# Altium schematic sheet Input.SchDoc(Input)
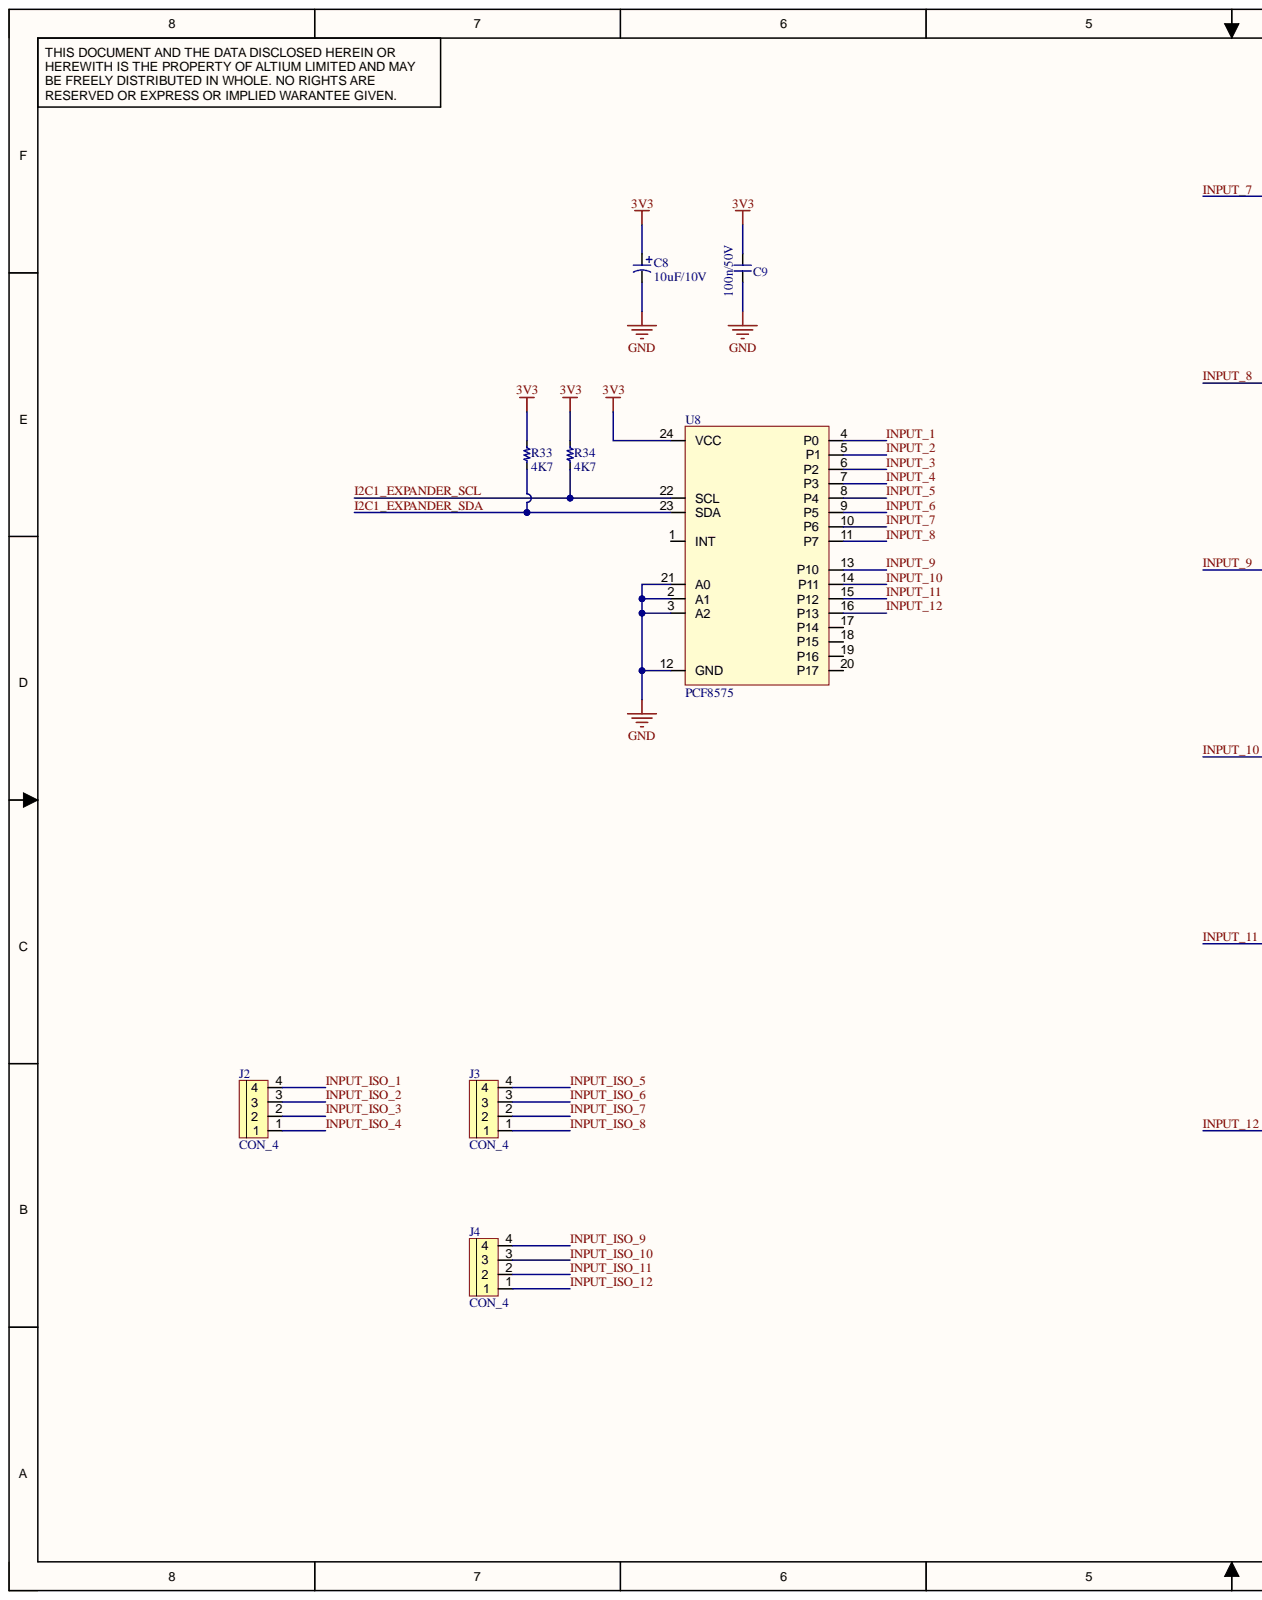
[diagram p0: Input.SchDoc, left]
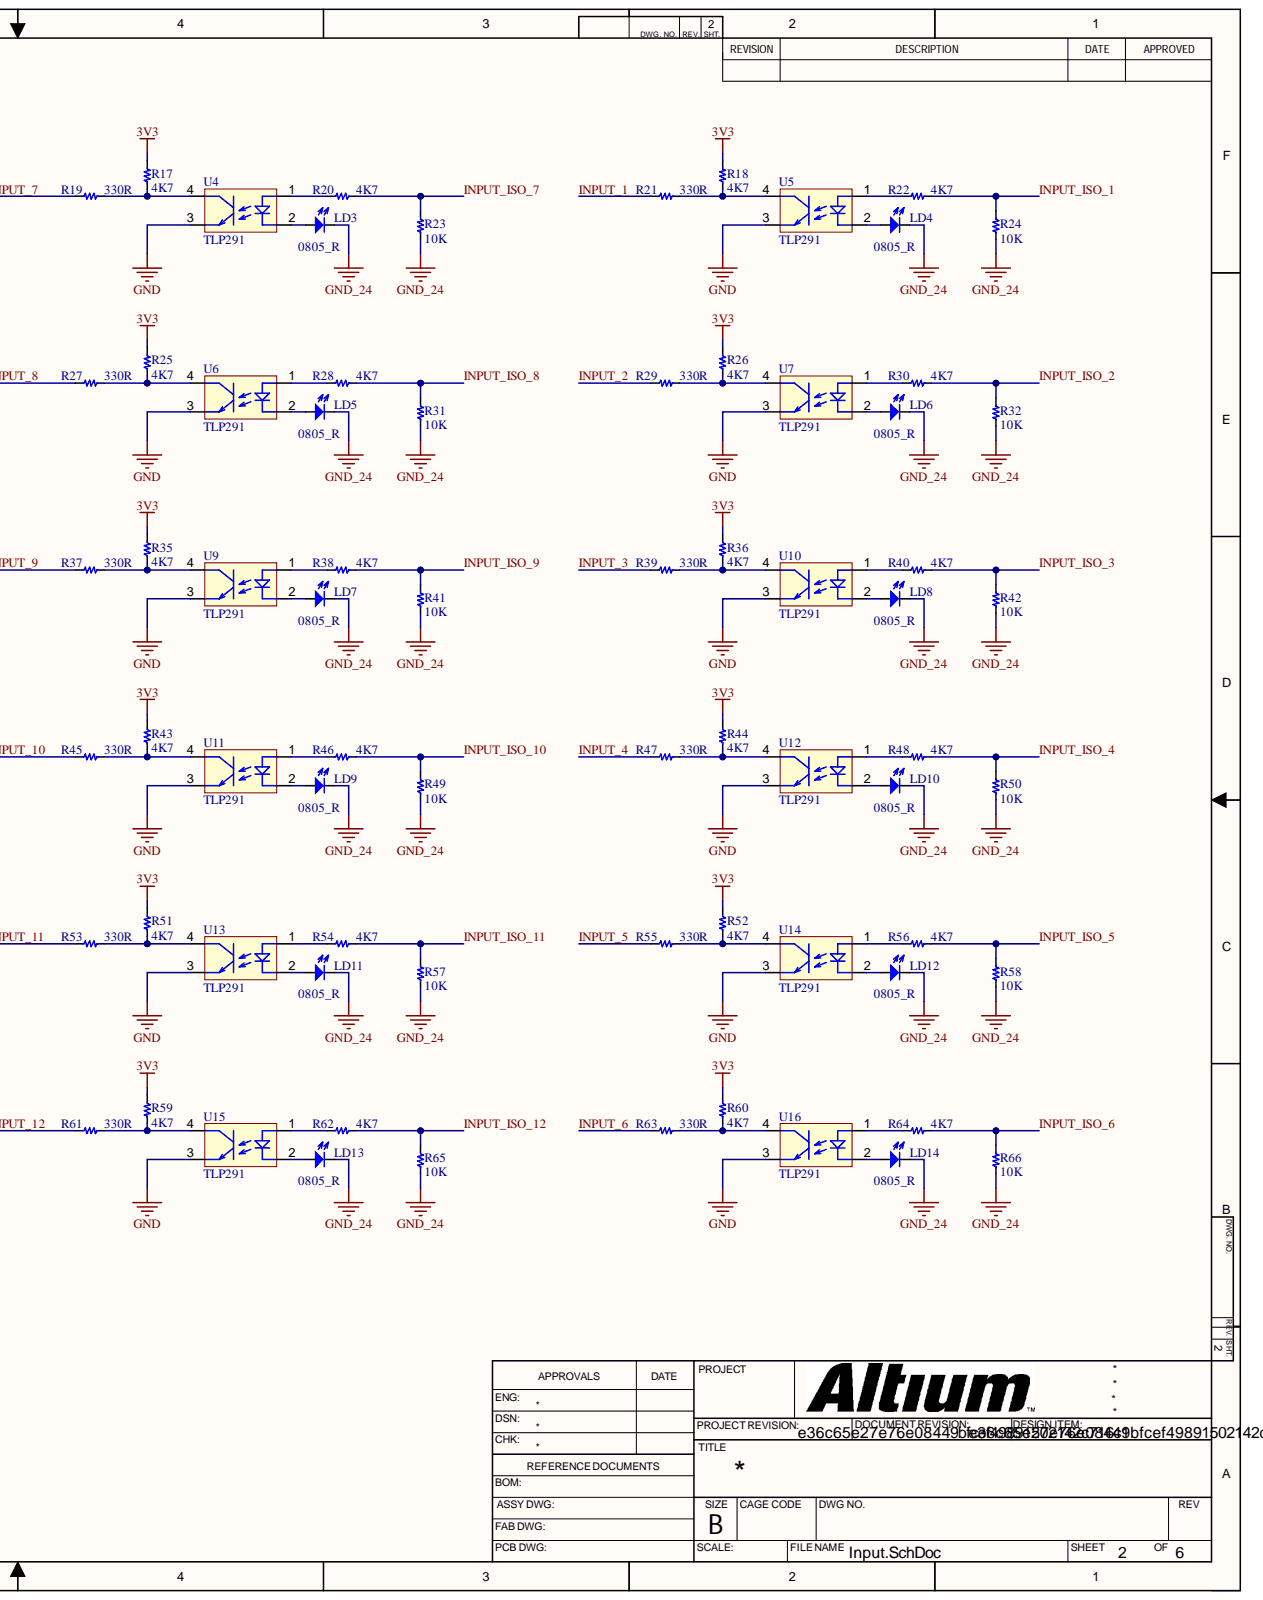
[diagram p1: Input.SchDoc, right]

## Parts
C8  10uF/10V  [CAP_TANTA_CASE_A]
C9  100n/50V  [C-0805]
J2  CON_4  [KF-5.0-4P]
J3  CON_4  [KF-5.0-4P]
J4  CON_4  [KF-5.0-4P]
LD3  0805_R  [LED_0805_R]
LD4  0805_R  [LED_0805_R]
LD5  0805_R  [LED_0805_R]
LD6  0805_R  [LED_0805_R]
LD7  0805_R  [LED_0805_R]
LD8  0805_R  [LED_0805_R]
LD9  0805_R  [LED_0805_R]
LD10  0805_R  [LED_0805_R]
LD11  0805_R  [LED_0805_R]
LD12  0805_R  [LED_0805_R]
LD13  0805_R  [LED_0805_R]
LD14  0805_R  [LED_0805_R]
R17  4K7  [R-0805]
R18  4K7  [R-0805]
R19  330R  [R-0805]
R20  4K7  [R-0805]
R21  330R  [R-0805]
R22  4K7  [R-0805]
R23  10K  [R-0805]
R24  10K  [R-0805]
R25  4K7  [R-0805]
R26  4K7  [R-0805]
R27  330R  [R-0805]
R28  4K7  [R-0805]
R29  330R  [R-0805]
R30  4K7  [R-0805]
R31  10K  [R-0805]
R32  10K  [R-0805]
R33  4K7  [R-0805]
R34  4K7  [R-0805]
R35  4K7  [R-0805]
R36  4K7  [R-0805]
R37  330R  [R-0805]
R38  4K7  [R-0805]
R39  330R  [R-0805]
R40  4K7  [R-0805]
R41  10K  [R-0805]
R42  10K  [R-0805]
R43  4K7  [R-0805]
R44  4K7  [R-0805]
R45  330R  [R-0805]
R46  4K7  [R-0805]
R47  330R  [R-0805]
R48  4K7  [R-0805]
R49  10K  [R-0805]
R50  10K  [R-0805]
R51  4K7  [R-0805]
R52  4K7  [R-0805]
R53  330R  [R-0805]
R54  4K7  [R-0805]
R55  330R  [R-0805]
R56  4K7  [R-0805]
R57  10K  [R-0805]
R58  10K  [R-0805]
R59  4K7  [R-0805]
R60  4K7  [R-0805]
R61  330R  [R-0805]
R62  4K7  [R-0805]
R63  330R  [R-0805]
R64  4K7  [R-0805]
R65  10K  [R-0805]
R66  10K  [R-0805]
U4  TLP291  [SOP-4-1.27mm]
U5  TLP291  [SOP-4-1.27mm]
U6  TLP291  [SOP-4-1.27mm]
U7  TLP291  [SOP-4-1.27mm]
U8  PCF8575  [SSOP-24-208MIL]
U9  TLP291  [SOP-4-1.27mm]
U10  TLP291  [SOP-4-1.27mm]
U11  TLP291  [SOP-4-1.27mm]
U12  TLP291  [SOP-4-1.27mm]
U13  TLP291  [SOP-4-1.27mm]
U14  TLP291  [SOP-4-1.27mm]
U15  TLP291  [SOP-4-1.27mm]
U16  TLP291  [SOP-4-1.27mm]

## Nets
3V3: C8.1 C9.2 R17.1 R18.1 R25.1 R26.1 R33.2 R34.2 R35.1 R36.1 R43.1 R44.1 R51.1 R52.1 R59.1 R60.1 U8.24(VCC)
GND: C8.2 C9.1 U4.3 U5.3 U6.3 U7.3 U8.2(A1) U8.3(A2) U8.12(GND) U8.21(A0) U9.3 U10.3 U11.3 U12.3 U13.3 U14.3 U15.3 U16.3
GND_24: LD3.2 LD4.2 LD5.2 LD6.2 LD7.2 LD8.2 LD9.2 LD10.2 LD11.2 LD12.2 LD13.2 LD14.2 R23.2 R24.2 R31.2 R32.2 R41.2 R42.2 R49.2 R50.2 R57.2 R58.2 R65.2 R66.2
I2C1_EXPANDER_SCL: R34.1 U8.22(SCL)
I2C1_EXPANDER_SDA: R33.1 U8.23(SDA)
INPUT_1: R21.1 U8.4(P0)
INPUT_2: R29.1 U8.5(P1)
INPUT_3: R39.1 U8.6(P2)
INPUT_4: R47.1 U8.7(P3)
INPUT_5: R55.1 U8.8(P4)
INPUT_6: R63.1 U8.9(P5)
INPUT_7: R19.1 U8.10(P6)
INPUT_8: R27.1 U8.11(P7)
INPUT_9: R37.1 U8.13(P10)
INPUT_10: R45.1 U8.14(P11)
INPUT_11: R53.1 U8.15(P12)
INPUT_12: R61.1 U8.16(P13)
INPUT_ISO_1: J2.4 R22.2 R24.1
INPUT_ISO_2: J2.3 R30.2 R32.1
INPUT_ISO_3: J2.2 R40.2 R42.1
INPUT_ISO_4: J2.1 R48.2 R50.1
INPUT_ISO_5: J3.4 R56.2 R58.1
INPUT_ISO_6: J3.3 R64.2 R66.1
INPUT_ISO_7: J3.2 R20.2 R23.1
INPUT_ISO_8: J3.1 R28.2 R31.1
INPUT_ISO_9: J4.4 R38.2 R41.1
INPUT_ISO_10: J4.3 R46.2 R49.1
INPUT_ISO_11: J4.2 R54.2 R57.1
INPUT_ISO_12: J4.1 R62.2 R65.1
NetLD3_1: LD3.1 U4.2
NetLD4_1: LD4.1 U5.2
NetLD5_1: LD5.1 U6.2
NetLD6_1: LD6.1 U7.2
NetLD7_1: LD7.1 U9.2
NetLD8_1: LD8.1 U10.2
NetLD9_1: LD9.1 U11.2
NetLD10_1: LD10.1 U12.2
NetLD11_1: LD11.1 U13.2
NetLD12_1: LD12.1 U14.2
NetLD13_1: LD13.1 U15.2
NetLD14_1: LD14.1 U16.2
NetR17_2: R17.2 R19.2 U4.4
NetR18_2: R18.2 R21.2 U5.4
NetR20_1: R20.1 U4.1
NetR22_1: R22.1 U5.1
NetR25_2: R25.2 R27.2 U6.4
NetR26_2: R26.2 R29.2 U7.4
NetR28_1: R28.1 U6.1
NetR30_1: R30.1 U7.1
NetR35_2: R35.2 R37.2 U9.4
NetR36_2: R36.2 R39.2 U10.4
NetR38_1: R38.1 U9.1
NetR40_1: R40.1 U10.1
NetR43_2: R43.2 R45.2 U11.4
NetR44_2: R44.2 R47.2 U12.4
NetR46_1: R46.1 U11.1
NetR48_1: R48.1 U12.1
NetR51_2: R51.2 R53.2 U13.4
NetR52_2: R52.2 R55.2 U14.4
NetR54_1: R54.1 U13.1
NetR56_1: R56.1 U14.1
NetR59_2: R59.2 R61.2 U15.4
NetR60_2: R60.2 R63.2 U16.4
NetR62_1: R62.1 U15.1
NetR64_1: R64.1 U16.1

Not connected: U8 1(INT) 17(P14) 18(P15) 19(P16) 20(P17)

## Library data of the parts
C8 = TANTALUM CAPACITOR: Component Kind: Standard; Library Name: NXVu.SchLib
C9 = CERAMIC_CAP: Component Kind: Standard; Library Name: NXVu.SchLib
LD3-LD14 = LED_0805_R: Component Kind: Standard; Library Name: NXVu.SchLib
R17-R66 = RESISTOR: Component Kind: Standard; Library Name: NXVu.SchLib
U4-U7 U9-U16 = PC817: Opto cuppler single  chanel; Component Kind: Standard; Library Name: NXVu.SchLib
U8 = PCF8575: Remote 16-bit I/O expander PCF8575 with interrupt output; Component Kind: Standard; Library Name: NXVu.SchLib
J2-J4 = CON_4: Component Kind: Standard; Library Name: NXVu.SchLib
